# Altium schematic sheet ECG.SchDoc
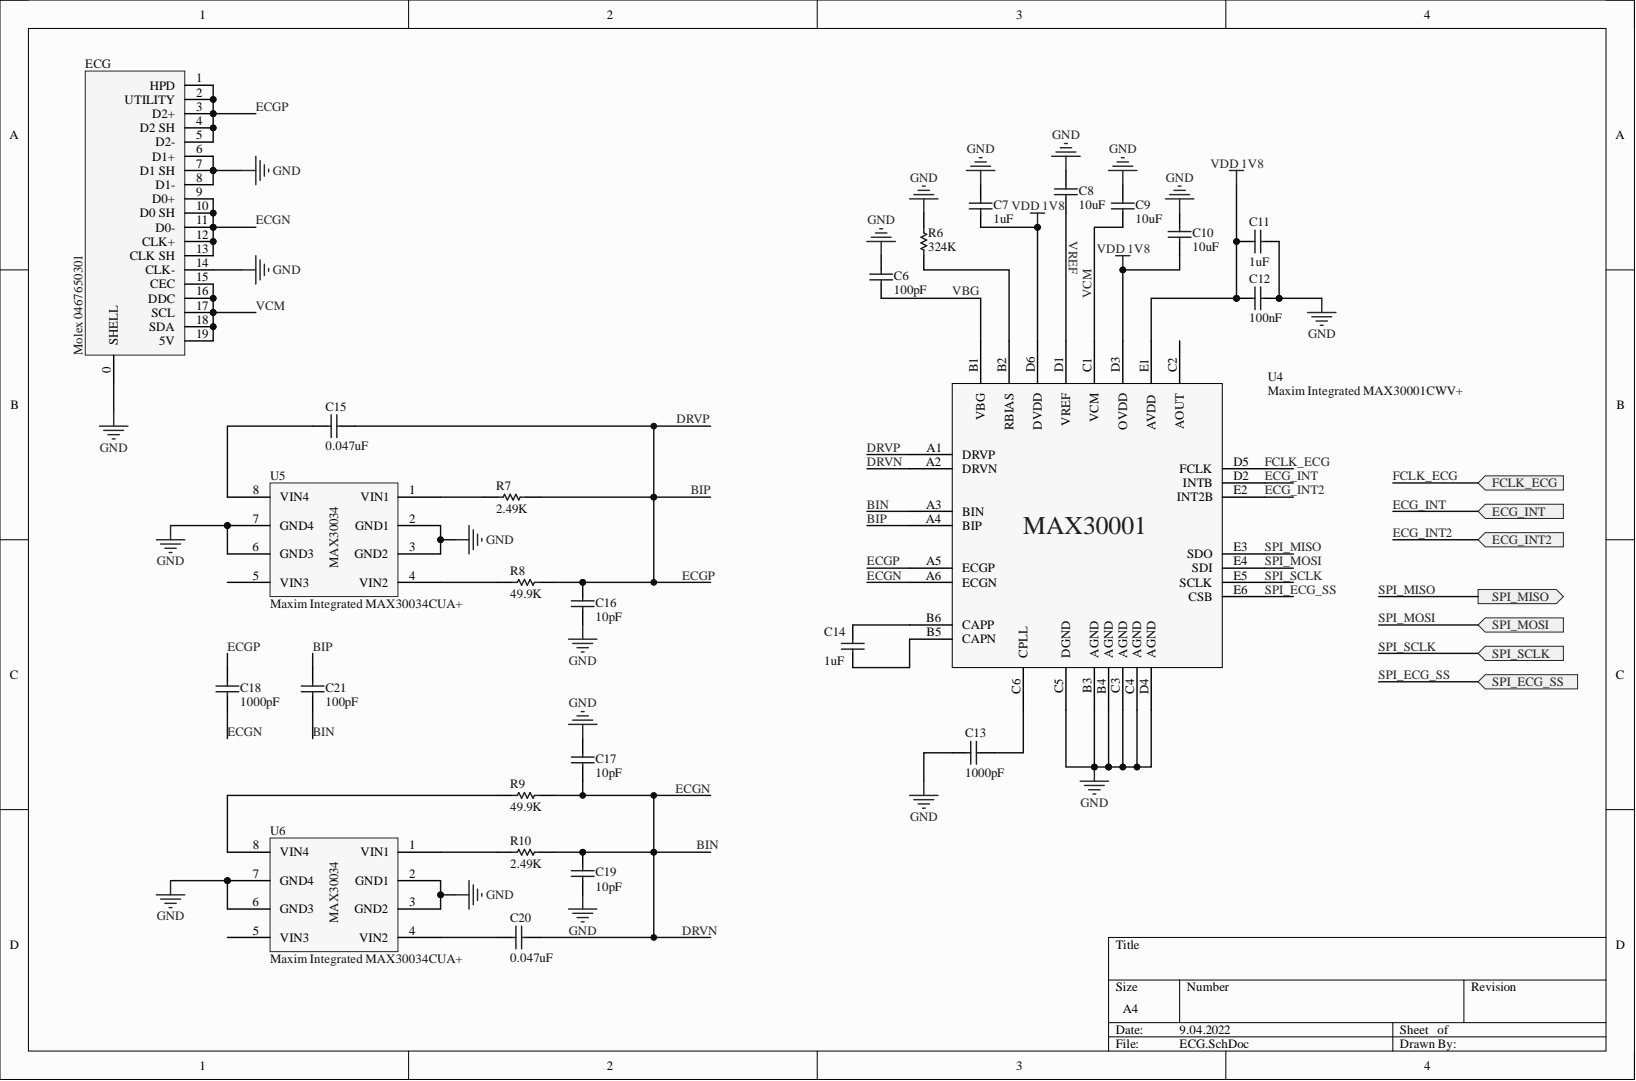
[diagram p0: ECG.SchDoc]

## Parts
C6  100pF  [CAPC1005X55X25ML05T10]
C7  1uF  [CAPC1005X55X25ML05T10]
C8  10uF  [CAPC1005X55X25ML05T10]
C9  10uF  [CAPC1005X55X25ML05T10]
C10  10uF  [CAPC1005X55X25ML05T10]
C11  1uF  [CAPC1005X55X25ML05T10]
C12  100nF  [CAPC1005X55X25ML05T10]
C13  1000pF  [CAPC1005X55X25ML05T10]
C14  1uF  [CAPC1005X55X25ML05T10]
C15  0.047uF  [CAPC1005X55X25ML05T10]
C16  10pF  [CAPC1005X55X25ML05T10]
C17  10pF  [CAPC1005X55X25ML05T10]
C18  1000pF  [CAP-0402_AP153]
C19  10pF  [CAPC1005X55X25ML05T10]
C20  0.047uF  [CAPC1005X55X25ML05T10]
C21  100pF  [CAPC1005X55X25ML05T10]
ECG  Molex 0467650301  [PCB-5lqscv3glygbq5lxxuom-1]
R6  324K  [RESC1005X40X25NL05T05]
R7  2.49K  [RESC1005X40X25NL05T05]
R8  49.9K  [RESC1005X40X25NL05T05]
R9  49.9K  [RESC1005X40X25NL05T05]
R10  2.49K  [RESC1005X40X25NL05T05]
U4  Maxim Integrated MAX30001CWV+  [BGA36x6]
U5  Maxim Integrated MAX30034CUA+  Manufacturer Part Number: MAX30034CUA+  [Quad8]
U6  Maxim Integrated MAX30034CUA+  Manufacturer Part Number: MAX30034CUA+  [Quad8]

## Ports
ECG_INT, ECG_INT2, FCLK_ECG, SPI_ECG_SS, SPI_MISO, SPI_MOSI, SPI_SCLK

## Nets
GND: C6.1 C7.2 C8.2 C9.2 C10.2 C11.1 C12.1 C13.2 C16.2 C17.2 C19.2 ECG.0(SHELL) ECG.6(D1+) ECG.7(D1_SH) ECG.8(D1-) ECG.14(CLK-) R6.2 U4.B3(AGND) U4.B4(AGND) U4.C3(AGND) U4.C4(AGND) U4.C5(DGND) U4.D4(AGND) U5.2(GND1) U5.3(GND2) U5.6(GND3) U5.7(GND4) U6.2(GND1) U6.3(GND2) U6.6(GND3) U6.7(GND4)
VDD 1V8: C7.1 C10.1 C11.2 C12.2 U4.D3(OVDD) U4.D6(DVDD) U4.E1(AVDD)
BIN: C17.1 C18.1 C19.1 C20.2 C21.2 ECG.9(D0+) ECG.10(D0_SH) ECG.11(D0-) ECG.12(CLK+) ECG.13(CLK_SH) R9.2 R10.2 U4.A2(DRVN) U4.A3(BIN) U4.A6(ECGN)
BIP: C15.2 C16.1 C18.2 C21.1 ECG.1(HPD) ECG.2(UTILITY) ECG.3(D2+) ECG.4(D2_SH) ECG.5(D2-) R7.2 R8.2 U4.A1(DRVP) U4.A4(BIP) U4.A5(ECGP)
ECG_INT: U4.D2(INTB) ; port ECG_INT
ECG_INT2: U4.E2(INT2B) ; port ECG_INT2
FCLK_ECG: U4.D5(FCLK) ; port FCLK_ECG
SPI_ECG_SS: U4.E6(CSB) ; port SPI_ECG_SS
SPI_MISO: U4.E3(SDO) ; port SPI_MISO
SPI_MOSI: U4.E4(SDI) ; port SPI_MOSI
SPI_SCLK: U4.E5(SCLK) ; port SPI_SCLK
VBG: C6.2 U4.B1(VBG)
VCM: C9.1 ECG.15(CEC) ECG.16(DDC) ECG.17(SCL) ECG.18(SDA) ECG.19(5V) U4.C1(VCM)
VREF: C8.1 U4.D1(VREF)
NetC13_1: C13.1 U4.C6(CPLL)
NetC14_1: C14.1 U4.B5(CAPN)
NetC14_2: C14.2 U4.B6(CAPP)
NetC15_1: C15.1 U5.8(VIN4)
NetC20_1: C20.1 U6.4(VIN2)
NetR6_1: R6.1 U4.B2(RBIAS)
NetR7_1: R7.1 U5.1(VIN1)
NetR8_1: R8.1 U5.4(VIN2)
NetR9_1: R9.1 U6.8(VIN4)
NetR10_1: R10.1 U6.1(VIN1)

Not connected: U4 C2(AOUT); U5 5(VIN3); U6 5(VIN3)

## Library data of the parts
C6-C12 C14-C17 C19-C21 = CMP-13045642-2: Multilayer Ceramic Capacitors MLCC - SMD/SMT 1.0UF 6.3V 20% 0402; Manufacturer Part Number: GRM155R70J105MA12D; Capacitance: 1e-06 F; Dielectric Characteristic: X7R; Capacitance Tolerance: ±20%; Component Kind: Standard; Library Name: CommunityVault; Lifecycle Status: Active; Octopart Url: https://octopart.com/grm155r70j105ma12d-murata-23261089; OctopartID: 2dc3e5abe704f204; RoHS: Compliant; Size-Length: 0.001 m; Size-Thickness: 0.0005 m; Size-Width: 0.0005 m; Voltage Rating (DC): 6.3 V
C13 = CMP-3552807-2: Multilayer Ceramic Capacitors MLCC - SMD/SMT 0.001uF 50Volts 2%; Manufacturer Part Number: GRM1555C1H102GA01D; Capacitance: 1e-09 F; Dielectric Characteristic: C0G/NP0; Lead-Free Status: Lead Free; Voltage Rating (DC): 50.0 V; Capacitance Tolerance: ±2%; Component Kind: Standard; Library Name: CommunityVault; Lifecycle Status: Active; Octopart Url: https://octopart.com/grm1555c1h102ga01d-murata-17838610; OctopartID: 3069f873271defae; REACH SVHC Compliance: No SVHC; RoHS: Compliant; Size-Length: 0.001 m; Size-Thickness: 0.0005 m; Size-Width: 0.0005 m
C18 = CMP-119409-3: Murata; Manufacturer Part Number: GRM1555C1H102JA01D; Capacitance: 1e-09 F; Capacitance Tolerance: ±5%; Dielectric Characteristic: C0G/NP0; Dielectric Material: Ceramic; Lead-Free Status: Lead Free; Voltage Rating (DC): 50.0 V; Insulation Resistance: 10000000000.0 Ω; Component Kind: Standard; Library Name: CommunityVault; Lifecycle Status: Active; Mounting Style: Surface Mount; Number of Pins: 2; Octopart Url: http://octopart.com/grm1555c1h102ja01d-murata-196863; OctopartID: 7564bc6d50fdaaa3; Packaging: Cut Tape (CT); REACH SVHC Compliance: No SVHC; RoHS: Compliant; Seller Landing  Url: https://octopart-clicks.com/click/track?country=US&ak=8157f166&sig=05ea6b7&sid=459&ppid=196863&vpid=1623459&ct=offers; Size-Height: 0.0005 m; Size-Length: 0.001 m; Size-Thickness: 0.0005 m; Size-Width: 0.0005 m
ECG = CMP-eafa23345c91353d-3: Conn Micro HDMI RCP 19 POS 0.4mm Solder RA SMD 19 Terminal 1 Port Micro HDMI T/R; Manufacturer Part Number: 46765-1001; Gender: Female; Number of Contacts: 19; Component Kind: Standard; Contact Material: Brass; Contact Plating: Gold; Flammability Rating: UL 94 V-0; Library Name: CommunityVault; Lifecycle Status: Not Listed by Manufacturer; Mounting Style: Horizontal, Surface Mount, PCB; Octopart URL: https://octopart.com/46765-1001-molex-12882701; OctopartID: eafa23345c91353d; Packaging: Cut Tape (CT); RoHS: Compliant
R6-R10 = CMP-1847010-2: Res Thick Film 0402 2.49K Ohm 1% 0.1W(1/10W) ±100ppm/C Molded SMD Automotive Punched T/R; Manufacturer Part Number: ERJ-2RKF2491X; Lead-Free Status: Lead Free; Resistance: 2490.0 Ω; Voltage Rating (DC): 50.0 V; Case/Package: 0402; Component Kind: Standard; Library Name: CommunityVault; Lifecycle Status: Not Listed by Manufacturer; Mounting Style: Surface Mount; Octopart Url: https://octopart.com/erj-2rkf2491x-panasonic-55421041; OctopartID: 90375a701d204ff0; Packaging: Cut Tape (CT); Power Rating: 0.1 W; REACH SVHC Compliance: No SVHC; Resistance Tolerance: ±1%; RoHS: Compliant; Size-Height: 0.00035 m; Size-Length: 0.001 m; Size-Width: 0.0005 m
U4 = CMP-7260bfc8916fada7-3: IC Biopotential Afe 30WLP; Supply Voltage (DC): 2.00 V (max), 1.10 V (min); Component Kind: Standard; Library Name: FavoritesLibrary; Lifecycle Status: Not Listed by Manufacturer; Manufacturer Part Number: MAX30001CWV+; Octopart URL: https://octopart.com/max30001cwv%2B-maxim+integrated-77776489; OctopartID: 7260bfc8916fada7; Operating Temperature: 0.00 °C (min); RoHS: Compliant
U5 U6 = CMP-5c329148a42583f5-1: IC Surge Suppression 10.3V 8UMAX; Lead-Free Status: Lead Free; Component Kind: Standard; Library Name: FavoritesLibrary; Lifecycle Status: Not Listed by Manufacturer; Octopart URL: https://octopart.com/max30034cua%2B-maxim+integrated-74505585; OctopartID: 5c329148a42583f5; Operating Temperature: 0.00 °C (min); RoHS: Compliant
